# Altium schematic sheet CW551_MainBoard_Joystick.SchDoc
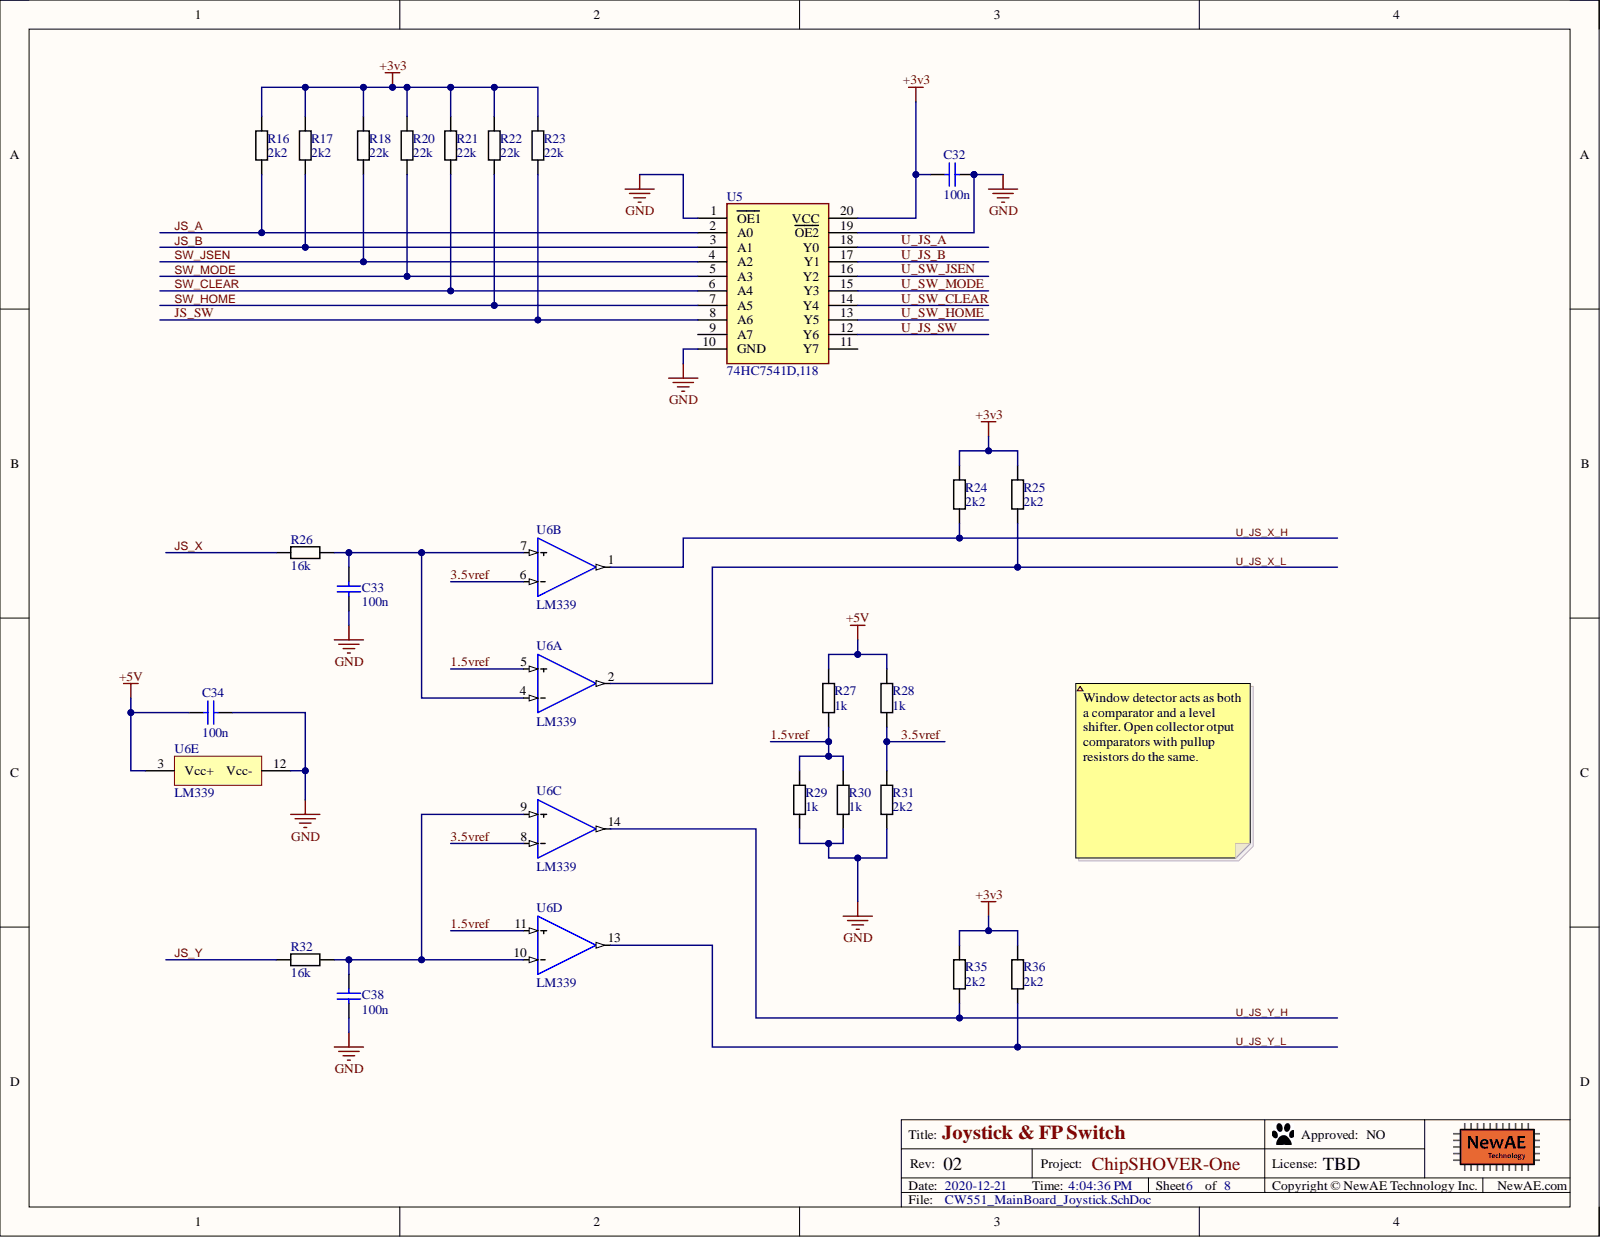
[diagram p0: CW551_MainBoard_Joystick.SchDoc]

## Parts
C32  100n  [0603]
C33  100n  [0603]
C34  100n  [0603]
C38  100n  [0603]
R16  2k2  [0603]
R17  2k2  [0603]
R18  22k  [0603]
R20  22k  [0603]
R21  22k  [0603]
R22  22k  [0603]
R23  22k  [0603]
R24  2k2  [0603]
R25  2k2  [0603]
R26  16k  [0603]
R27  1k  [0603]
R28  1k  [0603]
R29  1k  [0603]
R30  1k  [0603]
R31  2k2  [0603]
R32  16k  [0603]
R35  2k2  [0603]
R36  2k2  [0603]
U5  74HC7541D,118  [SOIC127P1032X265-20N]
U6  LM339  [SOIC127P600X135-14N]  (parts A,B,C,D,E)

## Nets
+3v3: C32.1 R16.1 R17.1 R18.1 R20.1 R21.1 R22.1 R23.1 R24.1 R25.1 R35.1 R36.1 U5.20(VCC)
+5V: C34.1 R27.1 R28.1 U6.3(Vcc+)
GND: C32.2 C33.1 C34.2 C38.1 R29.2 R30.2 R31.2 U5.1(OE1) U5.10(GND) U5.19(OE2) U6.12(Vcc-)
1.5vref: R27.2 R29.1 R30.1 U6.5 U6.11
3.5vref: R28.2 R31.1 U6.6 U6.8
JS_A: R16.2 U5.2(A0)
JS_B: R17.2 U5.3(A1)
JS_SW: R23.2 U5.8(A6)
JS_X: R26.1
JS_Y: R32.1
SW_CLEAR: R21.2 U5.6(A4)
SW_HOME: R22.2 U5.7(A5)
SW_JSEN: R18.2 U5.4(A2)
SW_MODE: R20.2 U5.5(A3)
U_JS_A: U5.18(Y0)
U_JS_B: U5.17(Y1)
U_JS_SW: U5.12(Y6)
U_JS_X_H: R24.2 U6.1
U_JS_X_L: R25.2 U6.2
U_JS_Y_H: R35.2 U6.14
U_JS_Y_L: R36.2 U6.13
U_SW_CLEAR: U5.14(Y4)
U_SW_HOME: U5.13(Y5)
U_SW_JSEN: U5.16(Y2)
U_SW_MODE: U5.15(Y3)
NetC33_2: C33.2 R26.2 U6.4 U6.7
NetC38_2: C38.2 R32.2 U6.9 U6.10

Not connected: U5 9(A7) 11(Y7)

## Library data of the parts
C32-C34 C38 = CCHIP-100n-0603: 100n, 0603; Manufacture 1: Kemet; Manufacture Part Number 1: C0603C104K5RACTU; Case-Metric: 1608; Component Group: Capacitor; Database Table Name: main; Rated Voltage: 50VDC; Case-EIA: 0603; Component Kind: Standard; Component Type: Standard; LCSC Equivalent: C1591; Library Name: NewAE_AltiumLib.DBLib; Mounting Technology: SMT; Part Number: CCHIP-100n-0603; Pin Count: 2; Supplier 1: Digi-Key; Supplier Part Number 1: 399-5089-2-ND; Value: 100n
R16 R17 R24 R25 R31 R35 R36 = RCHIP-2K2-0603: 2k2 res, 0603, 1%, 1/10W; Manufacture 1: Yageo; Manufacture Part Number 1: RC0603FR-072K2L; Case-Metric: 1608; Component Group: Resistor; Database Table Name: main; Rated Power: 0.1W; Rated Voltage: 75VDC; Case-EIA: 0603; Component Kind: Standard; Component Type: Standard; Library Name: NewAE_AltiumLib.DBLib; Mounting Technology: SMT; Part Number: RCHIP-2K2-0603; Pin Count: 2; Supplier 1: Digi-Key; Supplier Part Number 1: 311-2.20KHRCT-ND; Temperature Range: -55C to 155C; Tolerance: 1%; Value: 2k2
R18 R20-R23 = RCHIP-22K-0603: 22k res, 0603, 1%, 1/10W; Manufacture 1: Yageo; Manufacture Part Number 1: RC0603FR-0722KL; Case-Metric: 1608; Component Group: Resistor; Database Table Name: main; Rated Power: 0.1W; Rated Voltage: 75VDC; Case-EIA: 0603; Component Kind: Standard; Component Type: Standard; Library Name: NewAE_AltiumLib.DBLib; Mounting Technology: SMT; Part Number: RCHIP-22K-0603; Pin Count: 2; Supplier 1: Digi-Key; Supplier Part Number 1: 311-22.0KHRCT-ND; Temperature Range: -55C to 155C; Tolerance: 1%; Value: 22k
R26 R32 = RCHIP-16K-0603: 16k res, 0603, 1%, 1/10W; Manufacture 1: Yageo; Manufacture Part Number 1: RC0603FR-0716KL; Case-Metric: 1608; Component Group: Resistor; Database Table Name: main; Rated Power: 0.1W; Rated Voltage: 75VDC; Case-EIA: 0603; Component Kind: Standard; Component Type: Standard; Library Name: NewAE_AltiumLib.DBLib; Mounting Technology: SMT; Part Number: RCHIP-16K-0603; Pin Count: 2; Supplier 1: Digi-Key; Supplier Part Number 1: 311-16.0KHRCT-ND; Temperature Range: -55C to 155C; Tolerance: 1%; Value: 16k
R27-R30 = RCHIP-1K-0603: 1k res, 0603, 1%, 1/10W; Manufacture 1: Yageo; Manufacture Part Number 1: RC0603FR-071KL; Case-Metric: 1608; Component Group: Resistor; Database Table Name: main; Rated Power: 0.1W; Rated Voltage: 75VDC; Case-EIA: 0603; Component Kind: Standard; Component Type: Standard; Library Name: NewAE_AltiumLib.DBLib; Mounting Technology: SMT; Part Number: RCHIP-1K-0603; Pin Count: 2; Supplier 1: Digi-Key; Supplier Part Number 1: 311-1.00KHRCT-ND; Temperature Range: -55C to 155C; Tolerance: 1%; Value: 1k
U5 = 74HC7541D,118: Integrated Circuit; Manufacturer_Name: Nexperia; Arrow Part Number: 74HC7541D,118; Arrow Price/Stock: https://www.arrow.com/en/products/74hc7541d118/nexperia; Component Kind: Standard; Datasheet Link: https://assets.nexperia.com/documents/data-sheet/74HC_HCT7541.pdf; Height: 2.65mm; Manufacturer_Part_Number: 74HC7541D,118; Mouser Part Number: 771-74HC7541D-T; Mouser Price/Stock: https://www.mouser.co.uk/ProductDetail/Nexperia/74HC7541D118?qs=P62ublwmbi8VXtyI4hHtzA%3D%3D
U6 = LM339DT: IC COMPARATOR LP QUAD 14-SOIC OC OUTPUT; Manufacture 1: STMicroelectronics; Component Kind: Standard; Library Name: CW550_MAIN.SCHLIB; Manufacture Part Number: LM339DT; Supplier 1: Digi-Key; Supplier Part Number 1: 497-1588-1-ND
